# Altium schematic sheet CANBUS.SchDoc
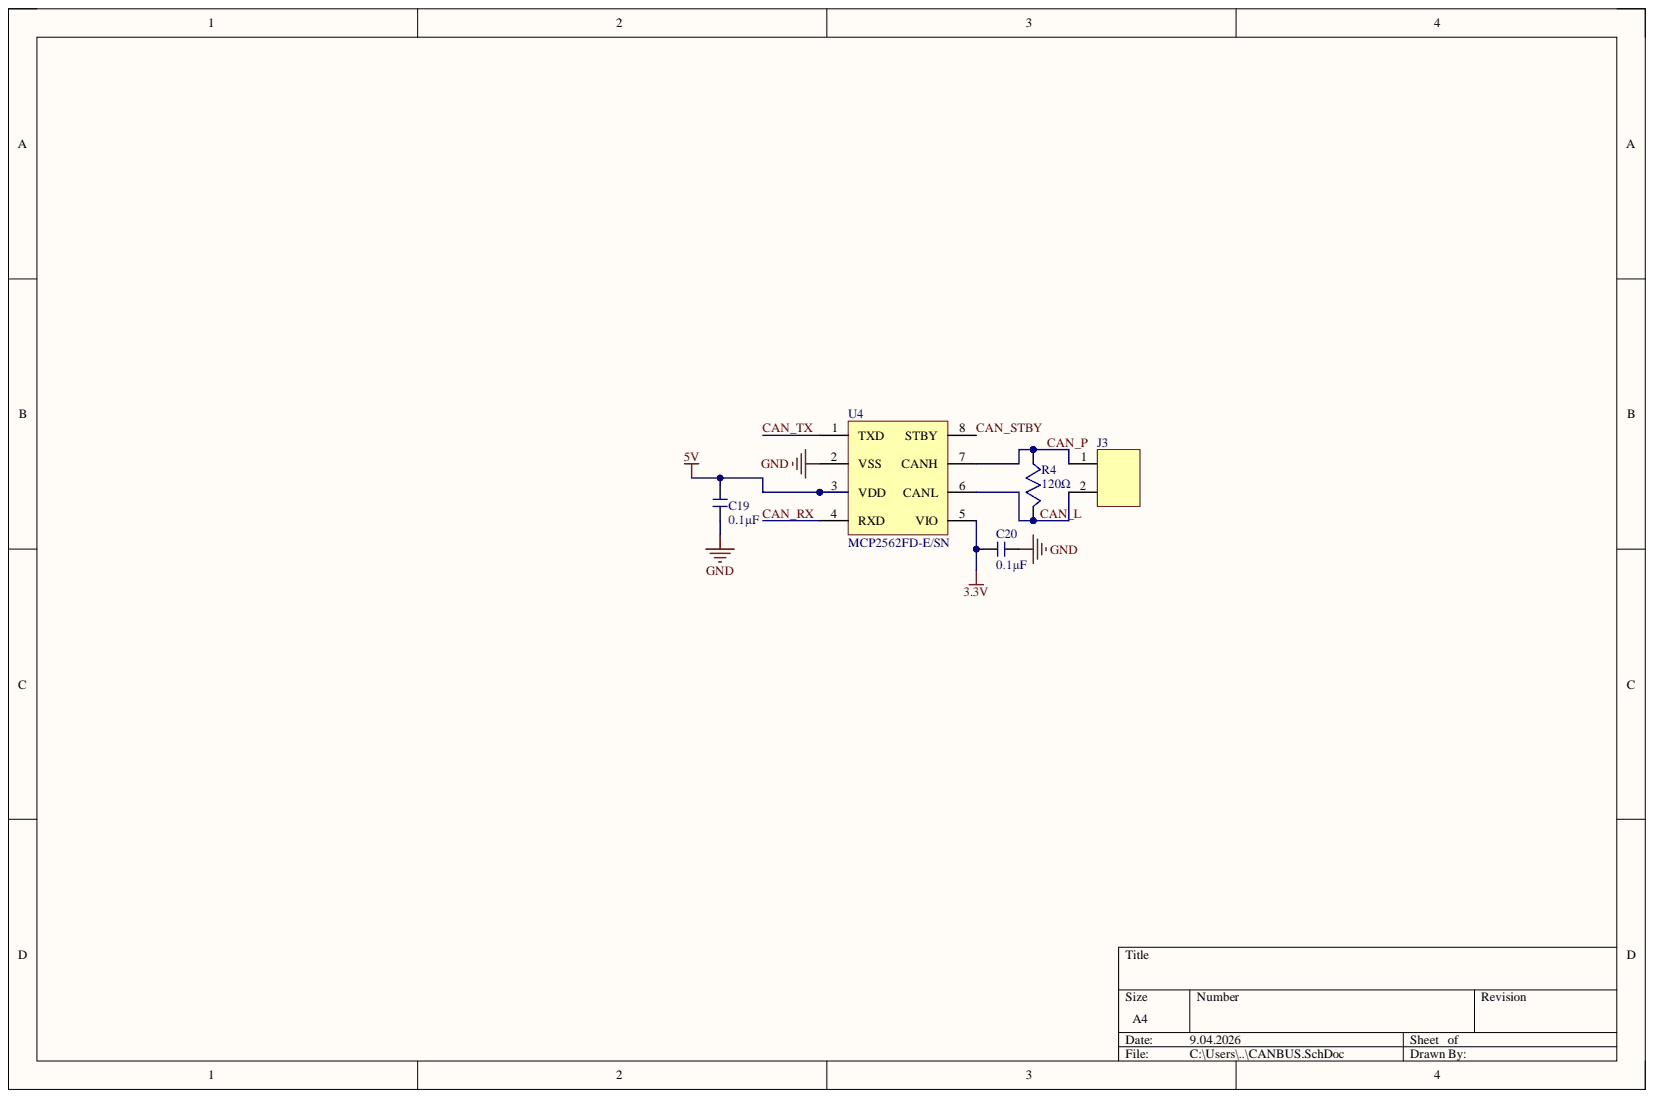
[diagram p0: CANBUS.SchDoc]

## Parts
C19  value 0.1µF  [402 capacitor - duplicate]  (hidden comment: 0402 capacitor)
C20  value 0.1µF  [402 capacitor - duplicate]  (hidden comment: 0402 capacitor)
J3  2 POS  [0901362102]  (value not drawn)
R4  value 120Ω  [402 RESISTOR]  (hidden comment: 0402. RESİSTOR)
U4  MCP2562FD-E/SN  [MCP2562FD-E/SN]

## Nets
3.3V: C20.2 U4.5(VIO)
5V: C19.2 U4.3(VDD)
GND: C19.1 C20.1 U4.2(VSS)
CAN_L: J3.2 R4.2 U4.6(CANL)
CAN_P: J3.1 R4.1 U4.7(CANH)
CAN_RX: U4.4(RXD)
CAN_STBY: U4.8(STBY)
CAN_TX: U4.1(TXD)

## Library data of the parts
C19 C20 = CAP SMD 402: MPN: CL05A104KA5NNNC; Component Kind: Standard; Description: CAP SMD 402; Library Name: Schlib1.SchLib; Package: 0402
J3 = 0901362102: CONN HEADER R/A 2POS 2.54MM; Component Kind: Standard; Library Name: Schlib1.SchLib; MPN: 0901362102
R4 = RES SMD 0402: MPN: ERJ-2RKF1200X; Component Kind: Standard; Description: RES SMD 0402; Library Name: Schlib1.SchLib; Package: 0402
U4 = MCP2562FD-E/SN: IC TRANSCEIVER 1/1 8SOIC; Component Kind: Standard; Library Name: Schlib1.SchLib; MPN: MCP2562FD-E/SN
